# Altium schematic sheet Main_AC.SchDoc(Main_AC)
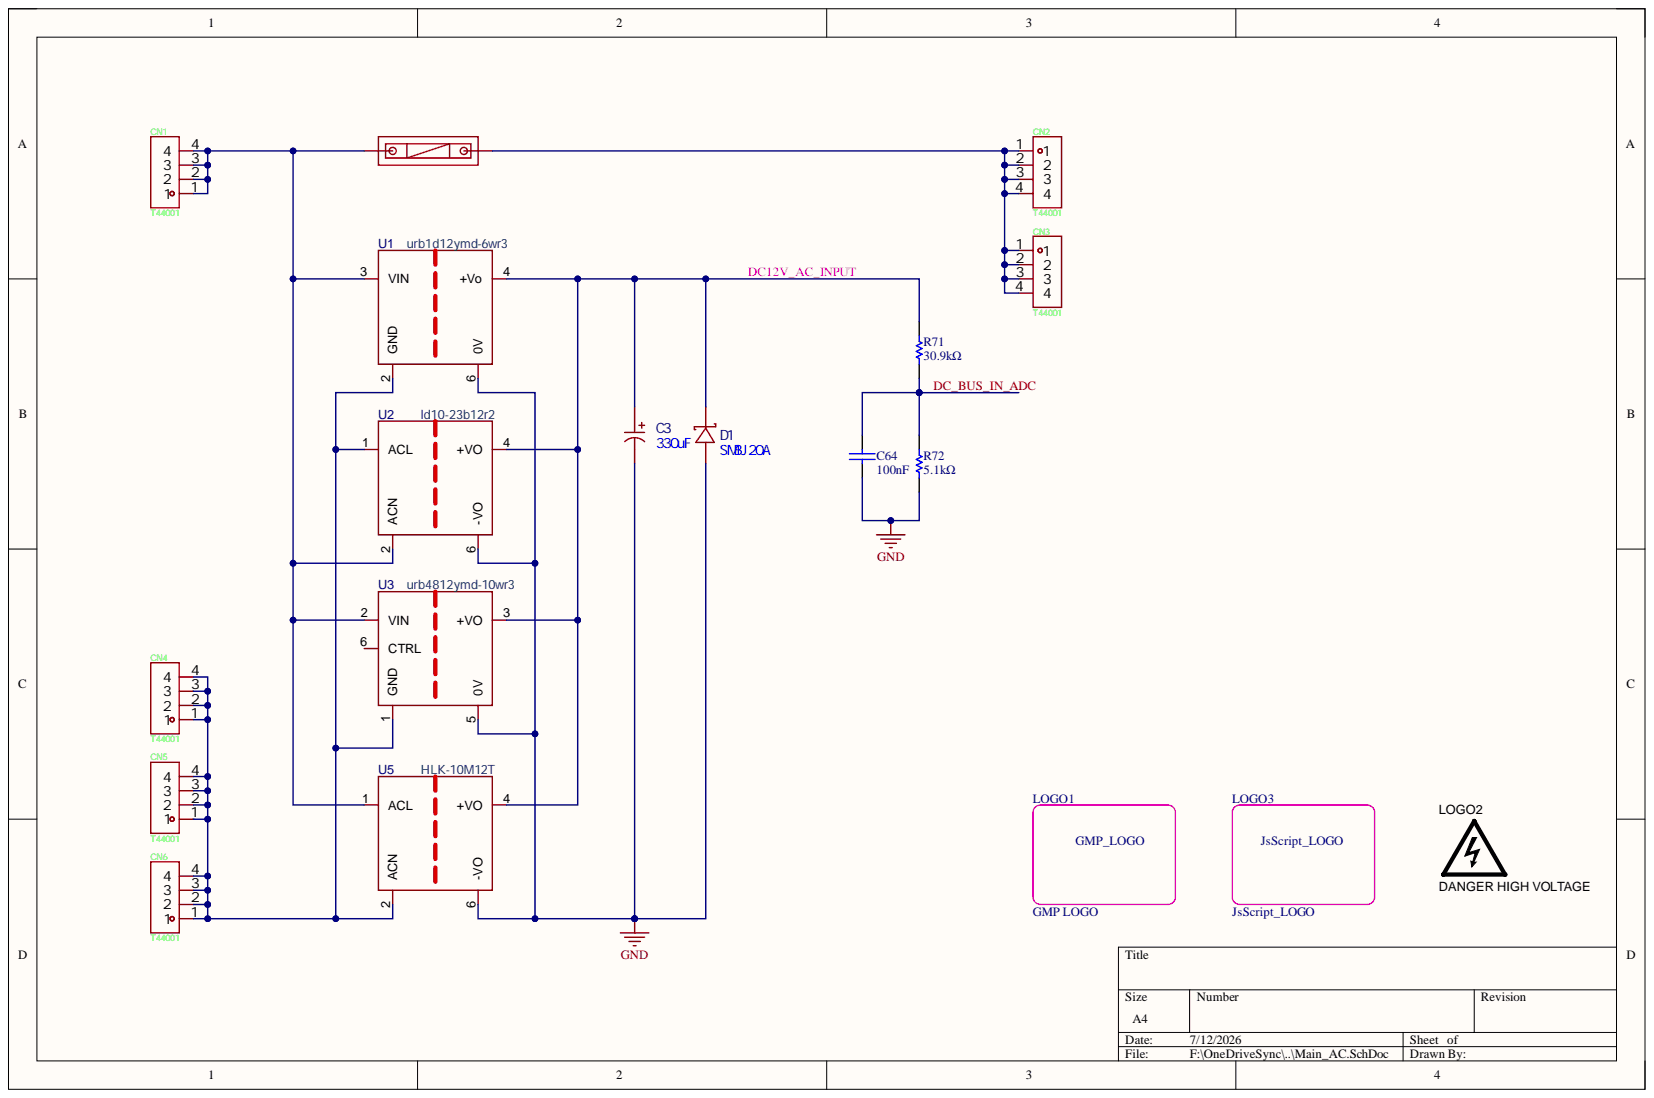
[diagram p0: Main_AC.SchDoc]

## Parts
C3  330uF  [CAP-SMD_BD8.0-L8.3-W8.3-FD]
C64  100nF  [0402C]
CN1  T44001  [CONN-TH_T44001]
CN2  T44001  [CONN-TH_T44001]
CN3  T44001  [CONN-TH_T44001]
CN4  T44001  [CONN-TH_T44001]
CN5  T44001  [CONN-TH_T44001]
CN6  T44001  [CONN-TH_T44001]
D1  SMBJ20A  [SMB_L4.6-W3.6-LS5.3-RD]
F1  保险丝透明座  [FUSE-TH_L24.5-W9.8-P22.5]  (value not drawn)
LOGO1  GMP LOGO  [GMP LOGO]
LOGO2  DANGER HIGH VOLTAGE  [IEC_HighVoltage_Medium]  (no BOM)
LOGO3  JsScript_LOGO  [JsScript-R-V2-test-update]
R71  30.9kΩ  [0402R]
R72  5.1kΩ  [0402R]
U1  urb1d12ymd-6wr3  [PWRM-TH_URB1DXXYMD-6WR3]
U2  ld10-23b12r2  [PWRM-TH_LD10-23BXXR2]
U3  urb4812ymd-10wr3  [PWRM-TH_URBXXXXYMD-10WR3]
U5  HLK-10M12T  [PWRM-TH_4P-L39.0-W23.5_HI-LINK_HLK-5MXXB]

## Nets
GND: C3.2 C64.1 D1.2 R72.1 U1.6(0V) U2.6(-VO) U3.5(0V) U5.6(-VO)
DC12V_AC_INPUT: C3.1 D1.1 R71.2 U1.4(+Vo) U2.4(+VO) U3.3(+VO) U5.4(+VO)
DC_BUS_IN_ADC: C64.2 R71.1 R72.2
NetCN1_1: CN1.1 CN1.2 CN1.3 CN1.4 F1.1 U1.3(VIN) U2.2(ACN) U3.2(VIN) U5.1(ACL)
NetCN2_1: CN2.1 CN2.2 CN2.3 CN2.4 CN3.1 CN3.2 CN3.3 CN3.4 F1.2
NetCN4_1: CN4.1 CN4.2 CN4.3 CN4.4 CN5.1 CN5.2 CN5.3 CN5.4 CN6.1 CN6.2 CN6.3 CN6.4 U1.2(GND) U2.1(ACL) U3.1(GND) U5.2(ACN)

Not connected: U3 6(CTRL)

## Library data of the parts
C3 = RVT1E331M0810 330UF 25V: C970701; Manufacturer: DMBJ(振宝佳); Add into BOM: yes; Lifetime: 2800hrs@105℃; Ripple Current: 228mA@120Hz; Tolerance: ±20%; Voltage Rating: 25V; Component Kind: Standard; Convert to PCB: yes; Datasheet: https://item.szlcsc.com/datasheet/RVT1E331M0810%2520330UF%252025V/1060429.html; Device: RVT1E331M0810 330UF 25V; Diameter: 8mm; Equivalent Series Resistance(ESR): -; Height - Seated (Max): 10.2mm; JLCPCB Part Class: 扩展库; LCSC Part Name: 330uF ±20% 25V; Manufacturer Part: RVT1E331M0810 330UF 25V; Operating Temperature: -55℃~+105℃; PCB3D: CAP-SMD_BD8.0-L8.3-W8.3-FD; Supplier: LCSC; Supplier Footprint: SMD,D8xL10.2mm; Supplier Part: C970701; Symbol: RVT1E331M0810 330UF 25V; Value: 330uF
C64 = CAP: 无极性电容; Component Kind: Standard; Library Name: 电容.IntLib
CN1-CN6 = T44001(PCB端子): Manufacturer: XFCN(兴飞); Add into BOM: yes; appearance and shape: 板凳状; Connectable Bits: 1; Direction of Connection: 顶部; Number of Leg: 4; Screw Hole Size: M4; Blade Width: -; Body Height: -; Body Material: -; Component Kind: Standard; Convert to PCB: yes; Datasheet: https://atta.szlcsc.com/upload/public/pdf/source/20241113/DBD34B45AB5A92804F3251B4AEFB1CE3.pdf; Device: T44001; JLCPCB Part Class: Extended Part; LCSC Part Name: 四脚 M4 2件套; Library Name: PowerLib.SchLib; Manufacturer Part: T44001; Ontology Coating: -; Operating Temperature: -; PCB3D: CONN-TH_T44001; Pin Spacing (Adjacent): -; Rated Current: -; Supplier: LCSC; Supplier Footprint: 插件; Supplier Part: C481447; Symbol: T44001
D1 = SMBJ20A_C151257: C151257; Manufacturer: Littelfuse(美国力特); Add into BOM: yes; Clamping Voltage: 32.4V; Peak Pulse Current (Ipp): 18.6A; Peak Pulse Power Dissipation (Ppp): 600W; Reverse Stand-Off Voltage (Vrwm): 20V; Type: TVS; Voltage - Breakdown: 22.2V; Component Kind: Standard; Convert to PCB: yes; Datasheet: https://item.szlcsc.com/datasheet/SMBJ20A/162597.html; Device: SMBJ20A_C151257; JLCPCB Part Class: 扩展库; LCSC Part Name: SMBJ20A; Manufacturer Part: SMBJ20A; PCB3D: SMB_L4.6-W3.6-LS5.3-RD; Supplier: LCSC; Supplier Footprint: SMB(DO-214AA); Supplier Part: C151257; Symbol: SMBJ20A_C151257
F1 = 保险丝透明座: 保险丝座类型:支座型;通道数:1;保险丝类型:圆柱;; Manufacturer: xcfuse(旭程); AddInBom: yes; Current Rating: 10A; Fuse Diameter (φD): 5.2mm; Fuse Length: 20mm; Fuse Type: 圆柱; Fuseholder Type: 支座型; ModelId: 2cf89099dda748e48bbc7794e1aba95b; ModelTransform: 952.754,354.33,0,0,0,0,0,0,-196.85; Number of Circuits: 1; Symbol: Fuse transparent seat; Voltage Rating: 250V; Component Kind: Standard; ConvertToPcb: yes; Datasheet: https://item.szlcsc.com/datasheet/%25E4%25BF%259D%25E9%2599%25A9%25E4%25B8%259D%25E9%2580%258F%25E6%2598%258E%25E5%25BA%25A7/59104.html; FootprintName: FUSE-TH_L24.5-W9.8-P22.5; JLCPCB Part Class: Extended Part; LCSC Part Name: 两件套 保险丝座 5*20 透明座(两件套); Library Name: Power.SchLib; ManufacturerPart: 保险丝透明座; ModelName: FUSE-TH_L24.5-W9.8-P22.5; Supplier: LCSC; Supplier Footprint: -; SupplierPart: C58066
LOGO1 = GMP_LOGO: LOGO GMP Main.; Component Kind: Standard; Library Name: GMP_PB_Core_Lib.SchLib
LOGO2 = IEC_HighVoltage: LOGO IEC 61010-1 High Voltage Warning; SymbolCreator: a0412235; LatestRevisionDate: 2017-07-06; Lib Reference: IEC_HighVoltage; PartNumber: DANGER HIGH VOLTAGE; Published: 2009-03-03; SymbolVersion: 2011-08-22; Library Name: GMP_PB_Core_Lib.SchLib; Publisher: Texas Instruments
LOGO3 = JsScript_LOGO: LOGO JsScript; Component Kind: Standard; Library Name: GMP_PB_Core_Lib.SchLib
R71 R72 = RES: Component Kind: Standard; Library Name: 电阻.IntLib
U1 = urb1d12ymd-6wr3: 是隔离 6W DC-DC 转换器产品，输入电压 40-160VDC，效率高达 86%，输入到输出隔离测试电压为 2250VDC，可在-40℃ 至 + 85℃ 的环境温度下安全运行，具备输入欠压保护、输出短路、过流、过压保护功能。; Manufacturer: MORNSUN(金升阳); AddInBom: yes; Capacitive Load(Max): 470uF; Conversion Efficiency: 84%; Isolation Voltage(Vrms): 2.25kV; ModelId: e99a44c88a784be181166c5d3c246947; ModelTransform: 999.998,999.998,0,0,0,0,0,0.591,-137.795; No-Load Input Current: 3mA; Number of Channels: 1; Output Current(Max): 500mA; Output Voltage: 12V; Ripple Noise: 100mVp-p; Value: 300kHz; Voltage - Supply: 40V~160V; Component Kind: Standard; ConvertToPcb: yes; Datasheet: https://item.szlcsc.com/datasheet/URB1D12YMD-6WR3/1060497.html; Features: 短路保护；过流保护；欠压保护；过压保护; FootprintName: PWRM-TH_URB1DXXYMD-6WR3; Height: 11.7mm; JLCPCB Part Class: Extended Part; LCSC Part Name: 6W隔离式DC-DC转换器; Length: 25.4mm; Library Name: Power.SchLib; ManufacturerPart: URB1D12YMD-6WR3; ModelName: PWRM-TH_URB1DXXYMD-6WR3; Operating Temperature: -40℃~+85℃; Output Power: 6W; Supplier: LCSC; Supplier Footprint: DIP,25.4x25.4mm; SupplierPart: C970754; Symbol: URB1D12YMD-6WR3; Width: 25.4mm
U2 = ld10-23b12r2: 超宽输入电压范围，交直流两用，低功耗，低纹波噪声，高效率，高可靠性，安全隔离。广泛应用于工业、电力、家电、仪表、通讯及民用等行业。; Manufacturer: MORNSUN(金升阳); AddInBom: yes; ModelId: fa665d95620949a8b5913d7b0e56cc1b; ModelTransform: 1574.24055,999.439,0,0,0,0,0,0,-137.795; Component Kind: Standard; ConvertToPcb: yes; Datasheet: https://item.szlcsc.com/datasheet/LD10-23B12R2/981326.html; FootprintName: PWRM-TH_LD10-23BXXR2; JLCPCB Part Class: Extended Part; LCSC Part Name: 10W AC-DC模块电源; Library Name: Power.SchLib; ManufacturerPart: LD10-23B12R2; ModelName: PWRM-TH_LD10-23BXXR2; Supplier: LCSC; Supplier Footprint: DIP,40x25.4mm; SupplierPart: C911416; Symbol: LD10-23B12R2
U3 = urb4812ymd-10wr3_c5369760: URB4812YMD-10WR3系列产品输出功率为10W,4:1超宽电压输入范围，效率高达91%，1500VDC的常规隔离电压允许工作温度-40℃~+85℃，具有输入欠压保护，输出过压、过流、 短路保护功能，裸机满足CISPR32/EN55032CLASSA,广泛应用于医疗、工控、电力、仪器仪表、通信、铁路等领域。; Manufacturer: YLPTEC(易川); AddInBom: yes; Capacitive Load(Max): 680uF; Conversion Efficiency: 89%; Isolation Voltage(Vrms): 1.5kV; ModelId: ce1fa9ceb8944a3ca88c4ae94f11cea0; ModelTransform: 999.998,999.998,0,0,0,0,0,0,-137.795; Number of Outputs: 1; Output Current(Max): 833mA; Output Power: 10W; Output Voltage: 12V; Ripple Noise: 50mVp-p; Value: 250kHz; Voltage - Supply: 18V~75V; Voltage Regulation: 稳压型; Component Kind: Standard; ConvertToPcb: yes; Datasheet: https://item.szlcsc.com/datasheet/URB4812YMD-10WR3/6212802.html; Features: 短路保护; FootprintName: PWRM-TH_URBXXXXYMD-10WR3; Height: 11mm; JLCPCB Part Class: Extended Part; LCSC Part Name: DC/DC电源模块，10W，超宽压输入，隔离稳压单路/双路输出; Length: 25.4mm; Library Name: Power.SchLib; ManufacturerPart: URB4812YMD-10WR3; ModelName: PWRM-TH_URBXXXXYMD-10WR3; Operating Temperature: -40℃~+85℃; Quiescent Supply Current: -; Supplier: LCSC; Supplier Footprint: DIP,25.4x25.4mm; SupplierPart: C5369760; Symbol: URB4812YMD-10WR3_C5369760; Type: -; Width: 25.4mm
U5 = ld10-23b12r2: 超宽输入电压范围，交直流两用，低功耗，低纹波噪声，高效率，高可靠性，安全隔离。广泛应用于工业、电力、家电、仪表、通讯及民用等行业。; Manufacturer: MORNSUN(金升阳); AddInBom: yes; ModelId: fa665d95620949a8b5913d7b0e56cc1b; ModelName: PWRM-TH_LD10-23BXXR2; ModelTransform: 1574.24055,999.439,0,0,0,0,0,0,-137.795; Component Kind: Standard; ConvertToPcb: yes; Datasheet: https://item.szlcsc.com/datasheet/LD10-23B12R2/981326.html; FootprintName: PWRM-TH_LD10-23BXXR2; JLCPCB Part Class: Extended Part; LCSC Part Name: 10W AC-DC模块电源; Library Name: Power.SchLib; ManufacturerPart: LD10-23B12R2; Supplier: LCSC; Supplier Footprint: DIP,40x25.4mm; SupplierPart: C911416; Symbol: LD10-23B12R2
